# Altium schematic sheet Setback.SchDoc
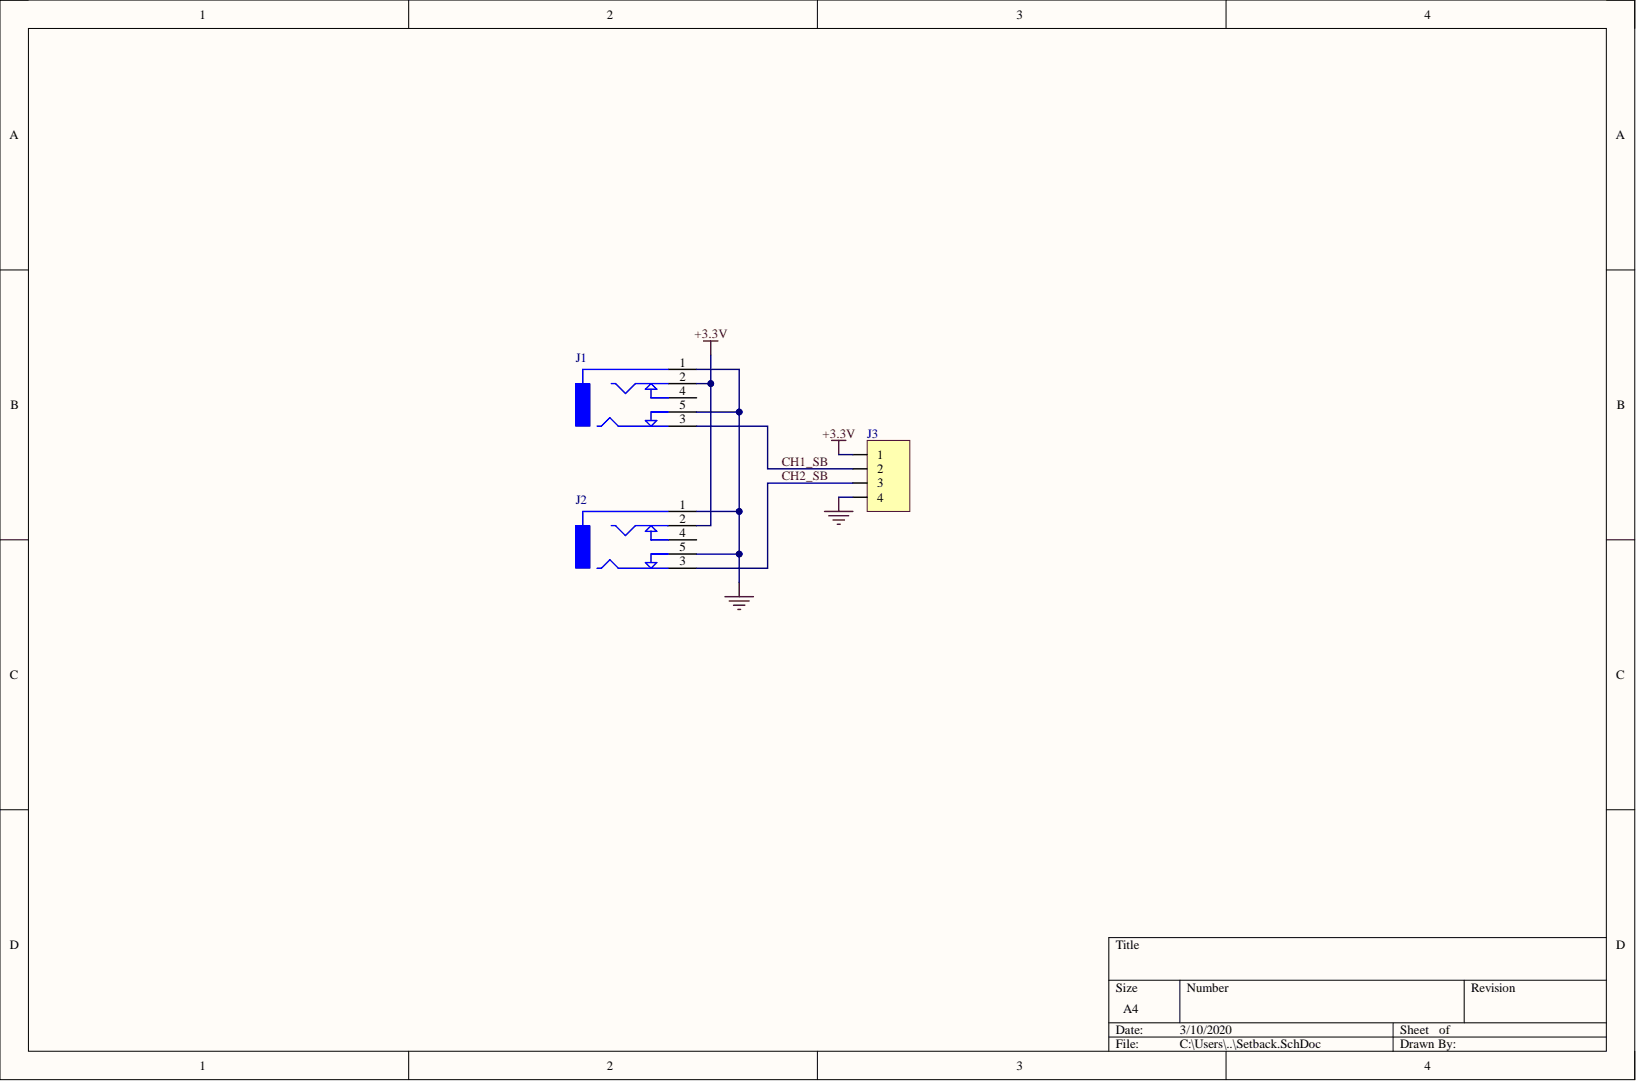
[diagram p0: Setback.SchDoc]

## Parts
J1  3.5mm Jack(stereo)  [3.5mmStereoSocket - CUI SJ1-3535]  (value not drawn)
J2  3.5mm Jack(stereo)  [3.5mmStereoSocket - CUI SJ1-3535]  (value not drawn)
J3  JST XH Connector, 4-Pin  [jst-b4b-xh-ax]  (value not drawn)

## Nets
+3.3V: J1.2 J2.2 J3.1
GND: J1.1 J1.5 J2.1 J2.5 J3.4
CH1_SB: J1.3 J3.2
CH2_SB: J2.3 J3.3

Not connected: J1 4; J2 4

## Library data of the parts
J1 J2 = 3.5mm Jack (CUI SJ1-353X): 3.5 mm Jack  CUI Devices SJ1-353X series (3535); Component Kind: Standard; Farnell PN: 1243244; Library Name: MyLibrary.IntLib
J3 = JST-B4B-XH-A: Component Kind: Standard; Description: JST XH Connector, 4-Pin; LatestRevisionDate: 17-Jul-2002; LatestRevisionNote: Re-released for DXP Platform.; Library Name: MyLibrary.IntLib; Signal Integrity: Connector; Publisher: Altium Limited
